# Altium schematic sheet Big Coil Gate Drive PCBA.SchDoc("Big Coil Gate Drive PCBA")
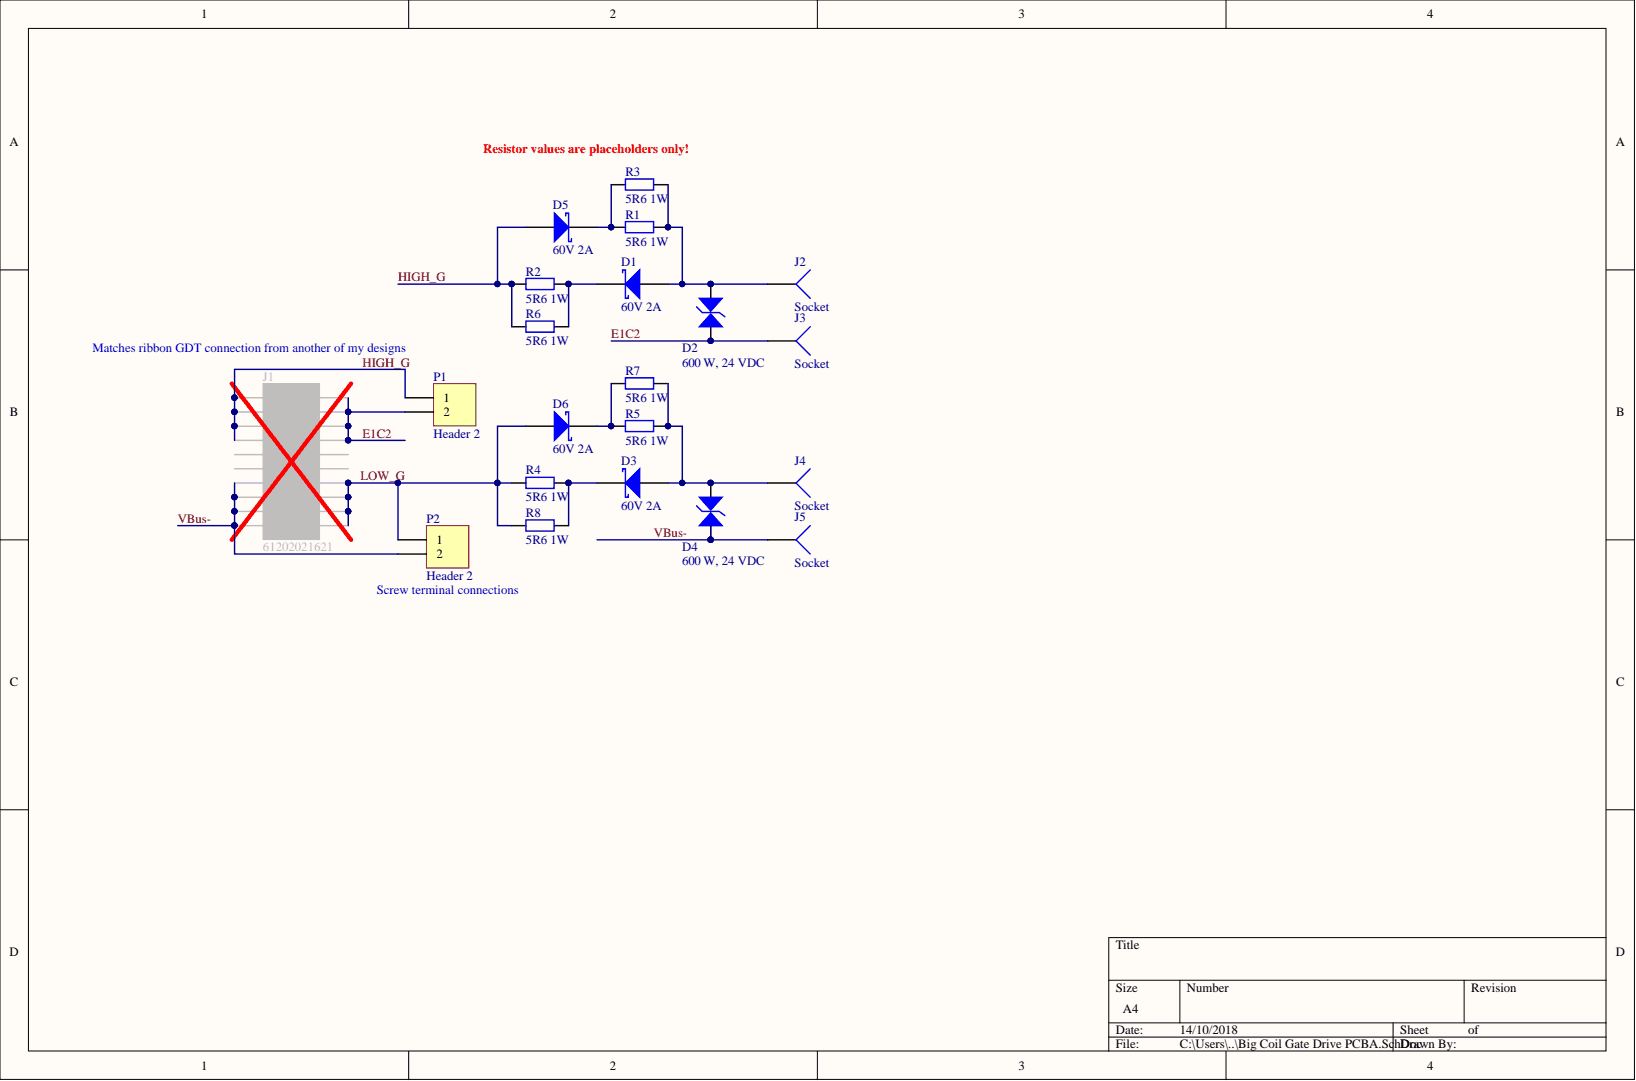
[diagram p0: Big Coil Gate Drive PCBA.SchDoc]

## Parts
D1  60V 2A  [DO-214AA_(SMB-J-BEND)_UNI]
D2  600 W, 24 VDC  [DO-214AA_Bidirectional]
D3  60V 2A  [DO-214AA_(SMB-J-BEND)_UNI]
D4  600 W, 24 VDC  [DO-214AA_Bidirectional]
D5  60V 2A  [DO-214AA_(SMB-J-BEND)_UNI]
D6  60V 2A  [DO-214AA_(SMB-J-BEND)_UNI]
J1  61202021621  [61202021621]
J2  Socket  [Keystone3534]
J3  Socket  [Keystone3534]
J4  Socket  [Keystone3534]
J5  Socket  [Keystone3534]
P1  Header 2  [691213510002]
P2  Header 2  [691213510002]
R1  value 5R6 1W  [RES_2512]  (hidden comment: Res2)
R2  value 5R6 1W  [RES_2512]  (hidden comment: Res2)
R3  value 5R6 1W  [RES_2512]  (hidden comment: Res2)
R4  value 5R6 1W  [RES_2512]  (hidden comment: Res2)
R5  value 5R6 1W  [RES_2512]  (hidden comment: Res2)
R6  value 5R6 1W  [RES_2512]  (hidden comment: Res2)
R7  value 5R6 1W  [RES_2512]  (hidden comment: Res2)
R8  value 5R6 1W  [RES_2512]  (hidden comment: Res2)

## Nets
E1C2: D2.2 J1.2 J1.4 J1.6 J1.8 J3.1 P1.2
HIGH_G: D5.A J1.1 J1.3 J1.5 J1.7 P1.1 R2.1 R6.1
LOW_G: D6.A J1.14 J1.16 J1.18 J1.20 P2.1 R4.1 R8.1
VBus-: D4.2 J1.13 J1.15 J1.17 J1.19 J5.1 P2.2
NetD1_A: D1.A D2.1 J2.1 R1.2 R3.2
NetD1_K: D1.K R2.2 R6.2
NetD3_A: D3.A D4.1 J4.1 R5.2 R7.2
NetD3_K: D3.K R4.2 R8.2
NetD5_K: D5.K R1.1 R3.1
NetD6_K: D6.K R5.1 R7.1

Not connected: J1 9 10 11 12

## Library data of the parts
D1 D3 D5 D6 = SS26: SS26 Schottky rectifier; Component Kind: Standard; Library Name: TV_Altium_library.SchDoc
D2 D4 = WE-TVSP DO-214AA, 824521241: WE-TVSP Power TVS Diode, bidirectional, 600 W, 24 VDC; Manufacturer: Wurth Elektronik; partNo: 824521241; Created Date: Nov.2015; ComponentLink2URL: http://katalog.we-online.de/pbs/datasheet/824521241.pdf; ComponentLink2Description: Datasheet; ComponentLink1URL: http://www.we-online.de; ComponentLink1Description: Manufacturer; Component Kind: Standard; Library Name: TV_Altium_library.SchDoc; Created by: Baojun Chen
J1 = 61202021621: WR-BHD 2.54mm Male Box Header; Manufacturer: Wurth Elektronik; NoPins: 20; ComponentLink2 Description: Datasheet; ComponentLink1 Description: Manufacturer; partNo: 61202021621; Created Date: March 2016; ComponentLink2URL: http://katalog.we-online.de/em/datasheet/6120xx21621.pdf; ComponentLink1URL: http://www.we-online.com; Component Kind: Standard; Library Name: TV_Altium_library.IntLib; Created by: Baojun Chen
P1 P2 = Header 2: Header, 2-Pin; Library Name: Miscellaneous Connectors.IntLib; LatestRevisionNote: Re-released for DXP Platform.; LatestRevisionDate: 17-Jul-2002; Component Kind: Standard; Publisher: Altium Limited
R1 R3-R8 = Res2: Resistor; Simulation: RESISTOR; Published: 8-Jun-2000; PackageReference: AXIAL-0.4; PackageDescription: Axial Device, Thru-Hole; 2 Leads; 0.4 in Pin Spacing; Library Name: Miscellaneous Devices.IntLib; LatestRevisionNote: Re-released for DXP Platform.; LatestRevisionDate: 17-Jul-2002; Component Kind: Standard; Publisher: Altium Limited
R2 = Res2: Resistor; Value: 5R6 1W; Simulation: RESISTOR; Published: 8-Jun-2000; PackageReference: AXIAL-0.4; PackageDescription: Axial Device, Thru-Hole; 2 Leads; 0.4 in Pin Spacing; Library Name: Miscellaneous Devices.IntLib; LatestRevisionNote: Re-released for DXP Platform.; LatestRevisionDate: 17-Jul-2002; Component Kind: Standard; Publisher: Altium Limited
J2-J5 = Socket: Library Name: Miscellaneous Connectors.IntLib; LatestRevisionNote: Re-released for DXP Platform.; LatestRevisionDate: 17-Jul-2002; Description: Socket; Component Kind: Standard; Publisher: Altium Limited
